# Altium schematic sheet PCF8563.SchDoc
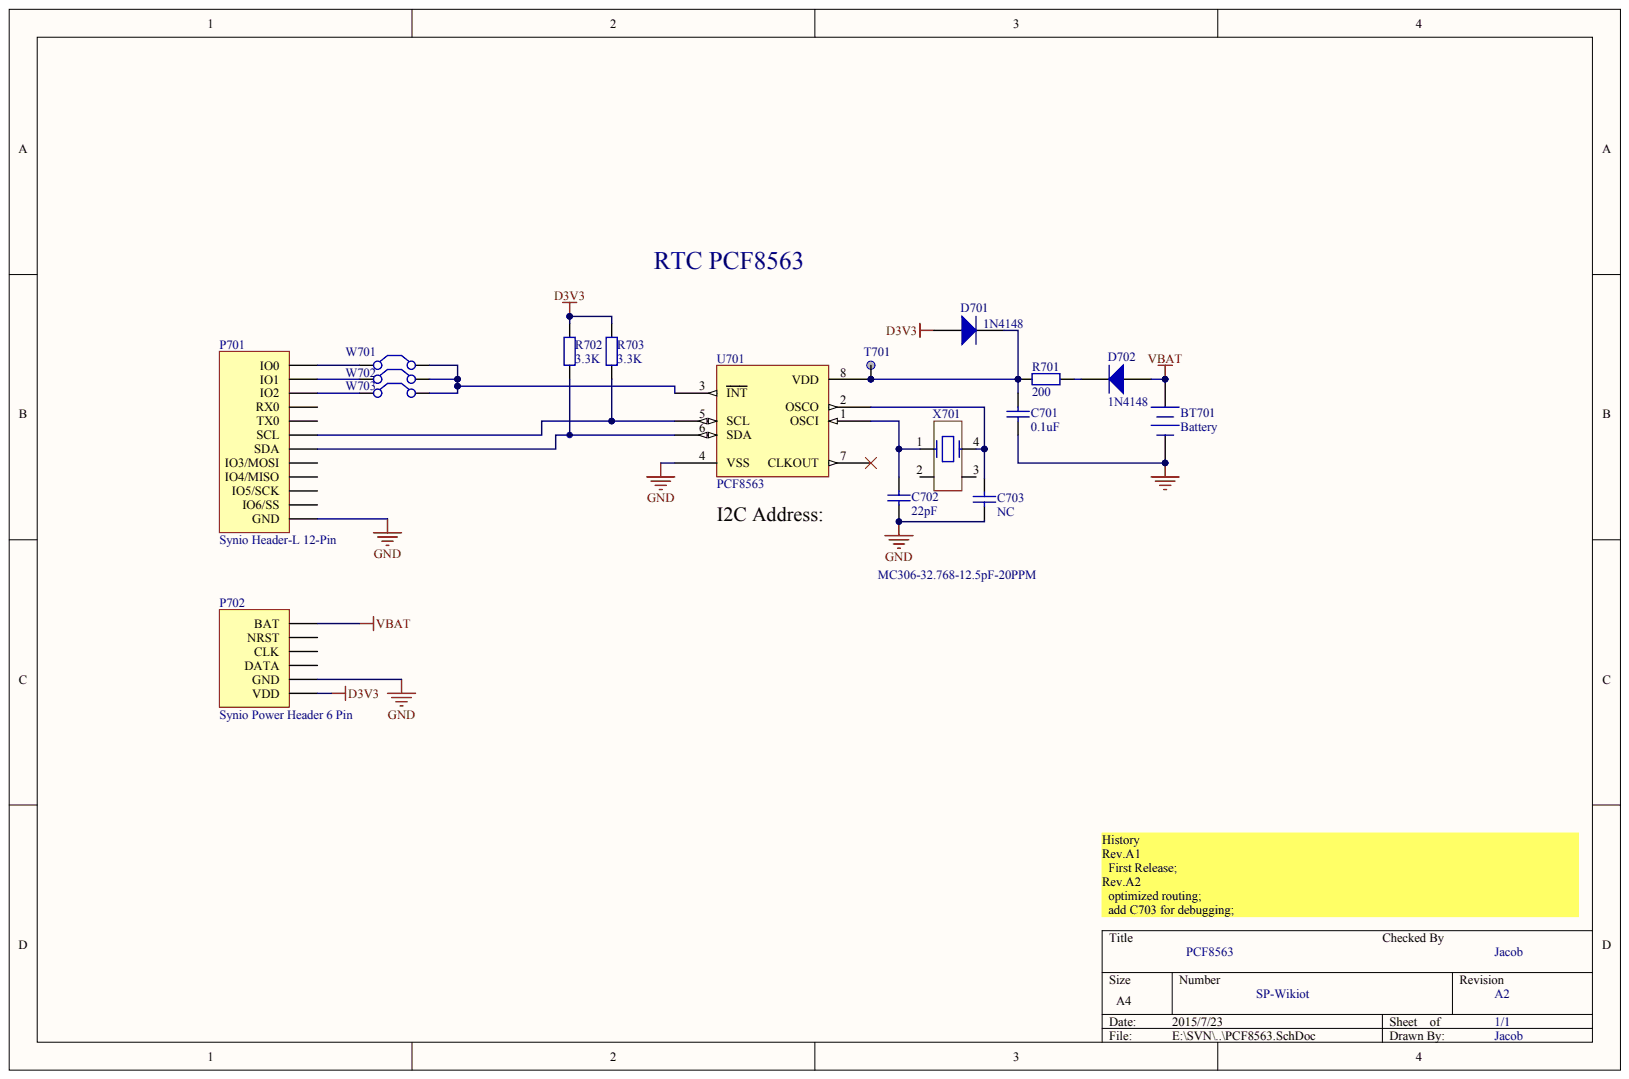
[diagram p0: PCF8563.SchDoc]

## Parts
BT701  Battery
C701  0.1uF
C702  22pF
C703  NC
D701  1N4148
D702  1N4148
P701  Synio Header-L 12-Pin
P702  Synio Power Header 6 Pin
R701  200
R702  3.3K
R703  3.3K
T701  TP  (value not drawn)
U701  PCF8563
W701  Jumper2-1  (value not drawn)
W702  Jumper2-1  (value not drawn)
W703  Jumper2-1  (value not drawn)
X701  MC306-32.768-12.5pF-20PPM

## Nets
D3V3: D701.1 P702.1(VDD) R702.2 R703.2
GND: BT701.2 C701.1 C702.1 C703.1 P701.1(GND) P702.2(GND) U701.4(VSS)
VBAT: BT701.1 D702.1 P702.6(BAT)
NetC701_2: C701.2 D701.2 R701.1 T701.1 U701.8(VDD)
NetC702_2: C702.2 U701.1(OSCI) X701.1
NetC703_2: C703.2 U701.2(OSCO) X701.4
NetD702_2: D702.2 R701.2
NetP701_6: P701.6(SDA) R702.1 U701.6(SDA)
NetP701_7: P701.7(SCL) R703.1 U701.5(SCL)
NetP701_10: P701.10(IO2) W703.1
NetP701_11: P701.11(IO1) W702.1
NetP701_12: P701.12(IO0) W701.1
NetU701_3: U701.3(INT) W701.2 W702.2 W703.2

Not connected: P701 2(IO6/SS) 3(IO5/SCK) 4(IO4/MISO) 5(IO3/MOSI) 8(TX0) 9(RX0); P702 3(DATA) 4(CLK) 5(NRST); U701 7(CLKOUT); X701 2 3

## Library data of the parts
BT701 C701-C703 D701 D702 P701 P702 R701-R703 T701 U701 W701-W703 X701 = (no symbol name)
  BT701: Published: 24-Mar-1999; LatestRevisionDate: 17-Jul-2002; LatestRevisionNote: Re-released for DXP Platform.; PackageReference: BAT-2; PackageDescription: Battery; 2 Leads
  C701: Published: 8-Jun-2000; LatestRevisionDate: 17-Jul-2002; LatestRevisionNote: Re-released for DXP Platform.; PackageReference: RAD-0.3; Value: 0.1uF
  C702: Published: 8-Jun-2000; LatestRevisionDate: 17-Jul-2002; LatestRevisionNote: Re-released for DXP Platform.; PackageReference: RAD-0.3; Value: 22pF
  C703: Published: 8-Jun-2000; LatestRevisionDate: 17-Jul-2002; LatestRevisionNote: Re-released for DXP Platform.; PackageReference: RAD-0.3; Value: NC
  D701 D702: Published: 8-Jun-2000; LatestRevisionDate: 17-Jul-2002; LatestRevisionNote: Re-released for DXP Platform.; DatasheetVersion: 1998; PackageVersion: Aug-1999; PackageReference: DO-41; PackageDescription: Diode, Axial; Body 5.2 x 2.7 mm (LxDia. max), Lead Dia. 0.86 mm (max); Code_JEDEC: DO-204-AL; ComponentLink1Description: Manufacturer Link; ComponentLink1URL: http://www.fairchildsemi.com/; ComponentLink2Description: Datasheet; ComponentLink2URL: http://www.fairchildsemi.com/ds/1N%2F1N4001.pdf
  P701: LatestRevisionDate: 17-Jul-2002; LatestRevisionNote: Re-released for DXP Platform.
  R701: Published: 8-Jun-2000; LatestRevisionDate: 17-Jul-2002; LatestRevisionNote: Re-released for DXP Platform.; PackageReference: AXIAL-0.4; Value: 200
  R702 R703: Published: 8-Jun-2000; LatestRevisionDate: 17-Jul-2002; LatestRevisionNote: Re-released for DXP Platform.; PackageReference: AXIAL-0.4; Value: 3.3K
  W701-W703: Published: 24-Mar-1999; LatestRevisionDate: 17-Jul-2002; LatestRevisionNote: Re-released for DXP Platform.; PackageReference: RAD-0.2
  BT701 D701 D702 P701 R701-R703 W701-W703: Publisher: Altium Limited
